# Altium schematic sheet Connection .SchDoc
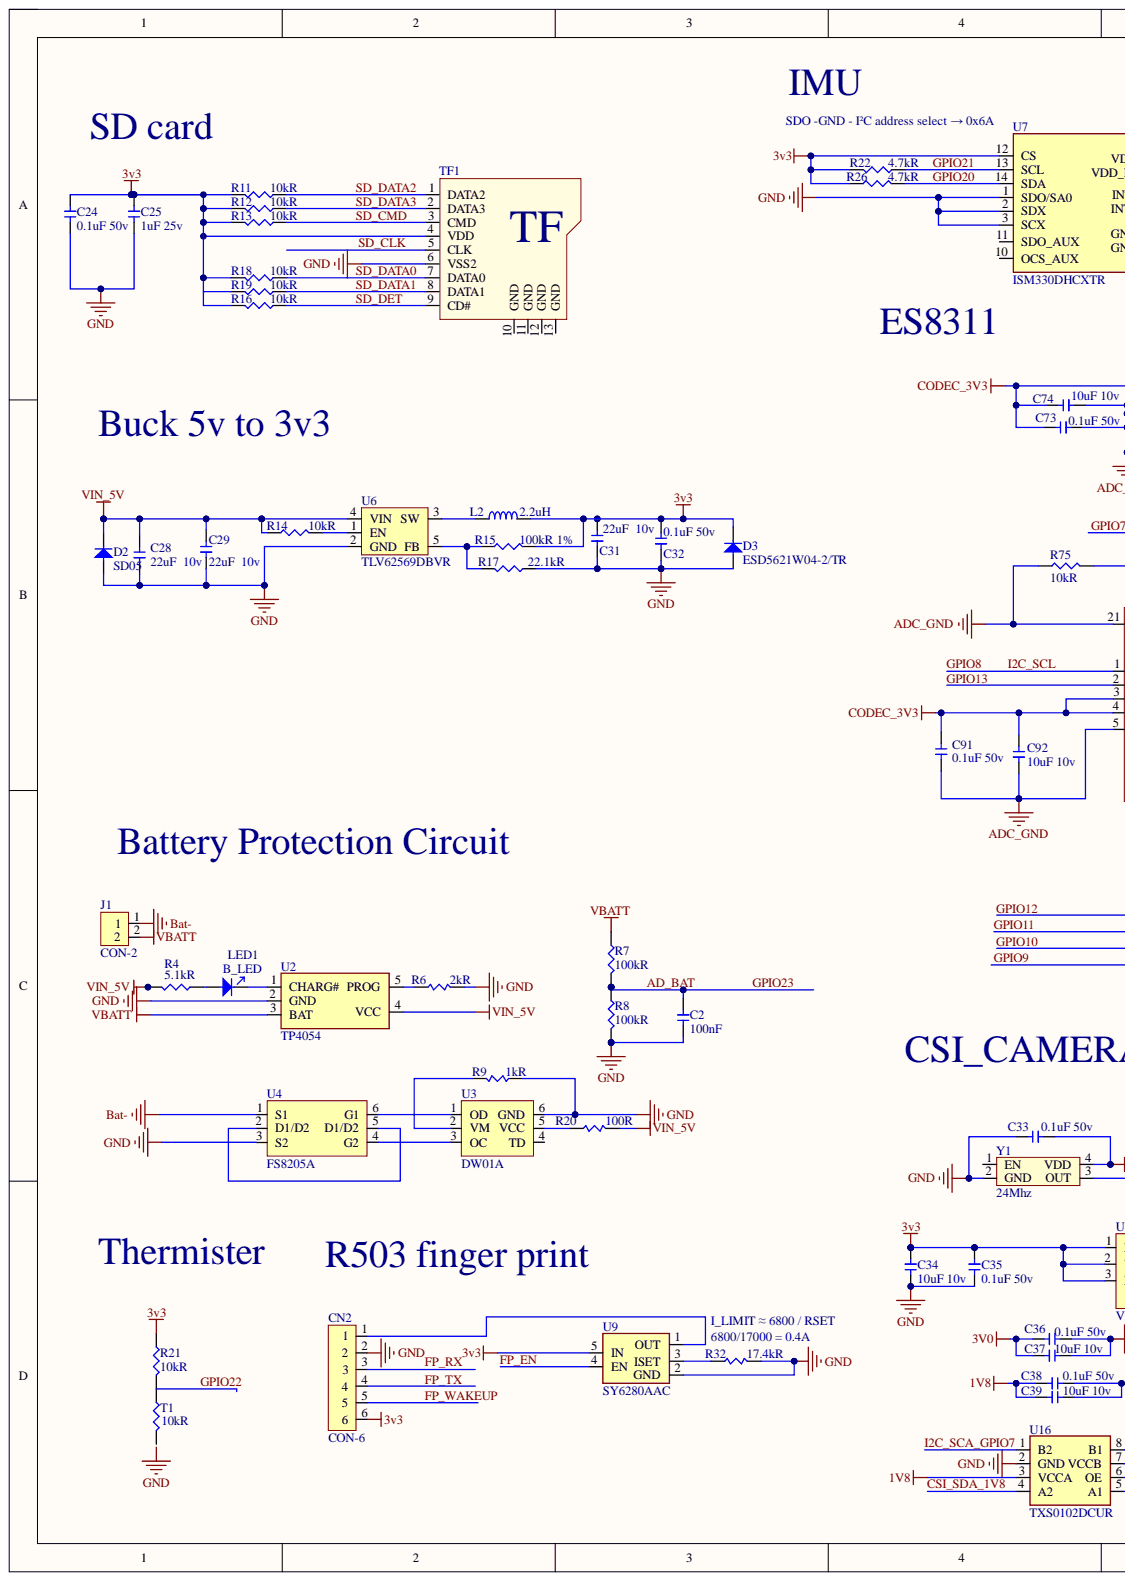
[diagram p0: Connection .SchDoc, left]
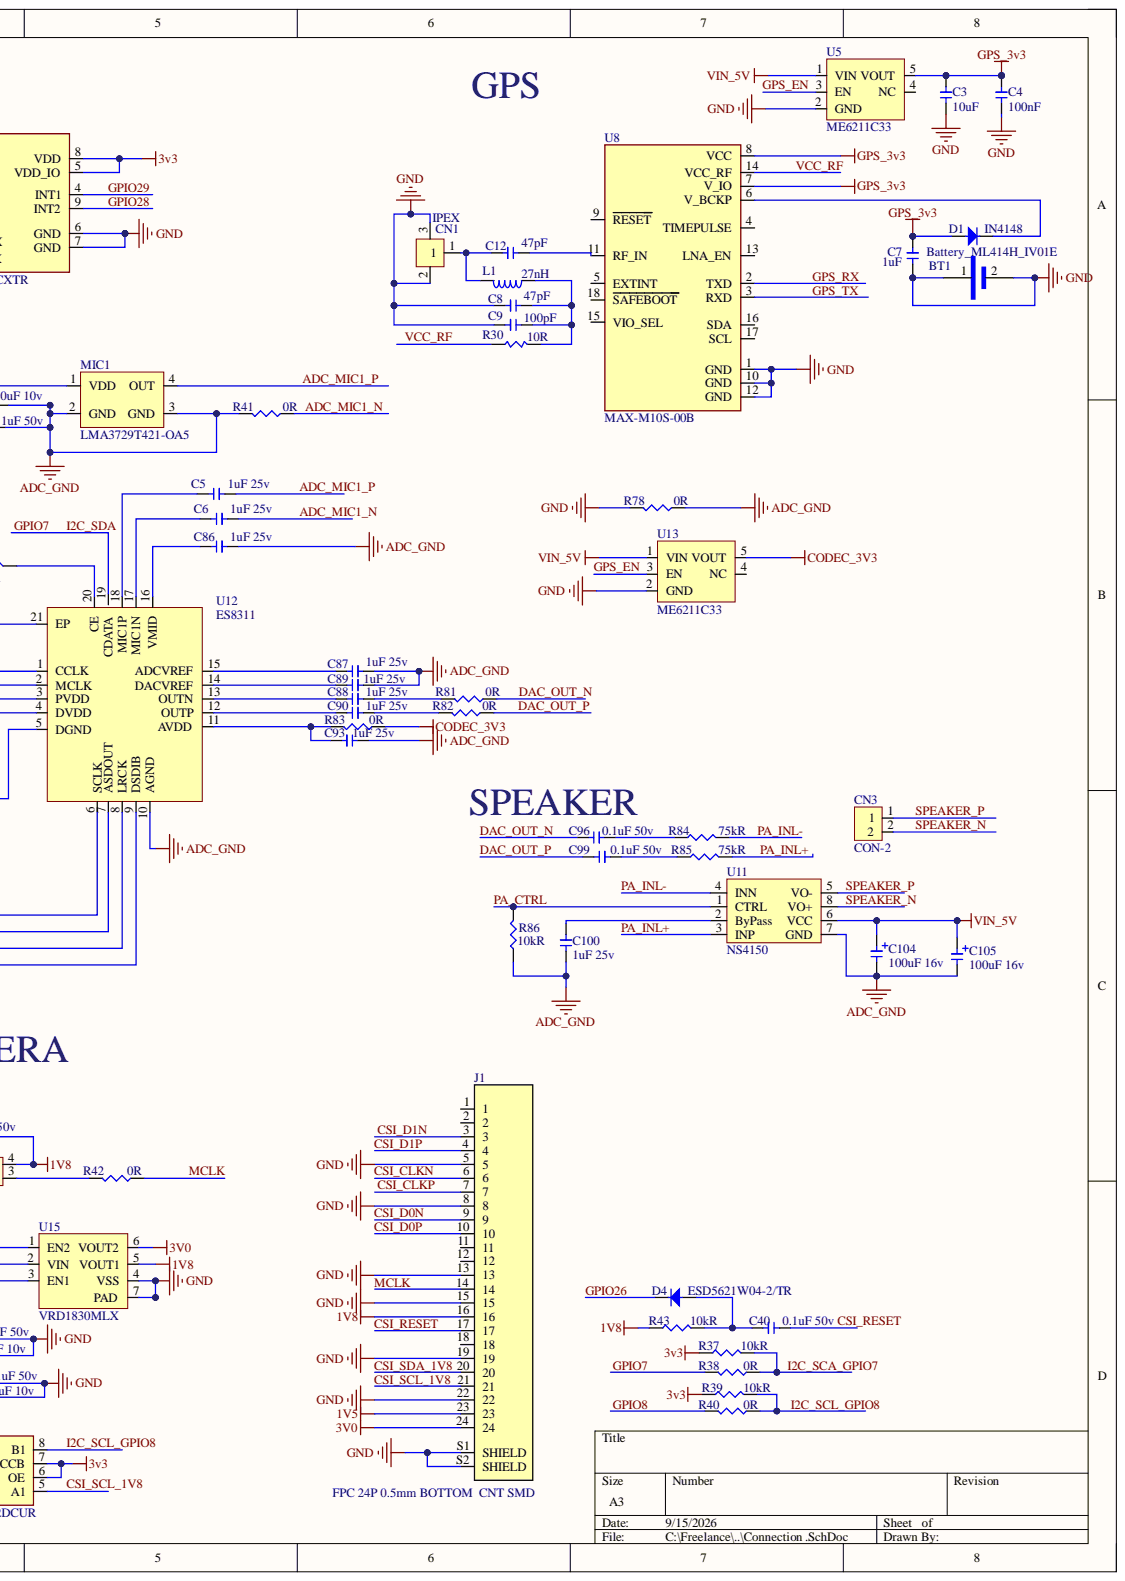
[diagram p1: Connection .SchDoc, right]

## Parts
BT1  Battery_ML414H_IV01E  [SEIKO_ML414H_IV01E]
C2  100nF  [0603C]
C3  10uF  [0603C]
C4  100nF  [0603C]
C5  1uF 25v  [0603C]
C6  1uF 25v  [0603C]
C7  1uF  [0603C]
C8  47pF  [0603C]
C9  100pF  [0603C]
C12  47pF  [0603C]
C24  0.1uF 50v  [0603C]
C25  1uF 25v  [0603C]
C28  22uF  10v  [0603C]
C29  22uF  10v  [0603C]
C31  22uF  10v  [0603C]
C32  0.1uF 50v  [0603C]
C33  0.1uF 50v  [0603C]
C34  10uF 10v  [0603C]
C35  0.1uF 50v  [0603C]
C36  0.1uF 50v  [0603C]
C37  10uF 10v  [0603C]
C38  0.1uF 50v  [0603C]
C39  10uF 10v  [0603C]
C40  0.1uF 50v  [0603C]
C73  0.1uF 50v  [0603C]
C74  10uF 10v  [0603C]
C86  1uF 25v  [0603C]
C87  1uF 25v  [0603C]
C88  1uF 25v  [0603C]
C89  1uF 25v  [0603C]
C90  1uF 25v  [0603C]
C91  0.1uF 50v  [0603C]
C92  10uF 10v  [0603C]
C93  1uF 25v  [0603C]
C96  0.1uF 50v  [0603C]
C99  0.1uF 50v  [0603C]
C100  1uF 25v  [0603C]
C104  100uF 16v  [1210C]
C105  100uF 16v  [1210C]
CN1  IPEX  [IPEX_20279-001E-03]
CN2  CON-6  [MOLEX 530470610 1.25MM 6P]
CN3  CON-2  [MOLEX 530470210 1.25mm 2p]
D1  IN4148  [SOD-123]
D2  SD05  [SOD323]
D3  ESD5621W04-2/TR  [SOD-323F]
D4  ESD5621W04-2/TR  [SOD-323F]
J1  FPC 24P 0.5mm BOTTOM  CNT SMD  [FPC 24P 0.5mm BOTTOM  CNT SMD]
J1  CON-2  [JST_S2B-PH-K-S(LF)(SN)]
L1  27nH  [0603L]
L2  2.2uH  [IND-3X3]
LED1  B_LED  [led0603 - blue]
MIC1  LMA3729T421-OA5  [SMD-4P_3.8x3mm]
R4  5.1kR  [0603]
R6  2kR  [0603]
R7  100kR  [0603]
R8  100kR  [0603]
R9  1kR  [0603]
R11  10kR  [0603]
R12  10kR  [0603]
R13  10kR  [0603]
R14  10kR  [0603]
R15  100kR 1%  [0603]
R16  10kR  [0603]
R17  22.1kR  [0603]
R18  10kR  [0603]
R19  10kR  [0603]
R20  100R  [0603]
R21  10kR  [0603]
R22  4.7kR  [0603]
R26  4.7kR  [0603]
R30  10R  [0603]
R32  17.4kR  [0603]
R37  10kR  [0603]
R38  0R  [0603]
R39  10kR  [0603]
R40  0R  [0603]
R41  0R  [0603]
R42  0R  [0603]
R43  10kR  [0603]
R75  10kR  [0603]
R78  0R  [0603]
R81  0R  [0603]
R82  0R  [0603]
R83  0R  [0603]
R84  75kR  [0603]
R85  75kR  [0603]
R86  10kR  [0603]
T1  10kR  [0603]
TF1  SD-MICRO-TF  [SD-MICRO-TF]  (value not drawn)
U2  TP4054  [SOT-23-5]
U3  DW01A  [SOT-23-6]
U4  FS8205A  [SOT-23-6]
U5  ME6211C33  [SOT-23-5]
U6  TLV62569DBVR  [SOT-23-5]
U7  ISM330DHCXTR  [VFLGA-14]
U8  MAX-M10S-00B  [XCVR_MAX-M10S-00B]
U9  SY6280AAC  [SOT95P285X130-5N]
U11  NS4150  [MSOP8]
U12  ES8311  [QFN-20]
U13  ME6211C33  [SOT-23-5]
U15  VRD1830MLX  [LLP-6(1.8x2)]
U16  TXS0102DCUR  [SOP50P310X90-8N]
Y1  24Mhz  [XTAL-3225]

## Nets
1V5: J1.23
1V8: C33.1 C38.1 C39.1 J1.16 R43.1 U15.5(VOUT1) U16.3(VCCA) Y1.4(VDD)
3V0: C24.1 C25.1 C31.2 C32.2 C34.1 C35.1 C36.1 C37.1 CN2.6 D3.C J1.24 L2.2 R11.2 R12.2 R13.2 R15.2 R16.2 R18.2 R19.2 R21.1 R22.2 R26.1 R37.1 R39.1 TF1.4(VDD) U7.5(VDD_IO) U7.8(VDD) U7.12(CS) U9.5(IN) U15.1(EN2) U15.2(VIN) U15.3(EN1) U15.6(VOUT2) U16.6(OE) U16.7(VCCB)
ADC_GND: C73.1 C74.1 C86.1 C87.1 C89.1 C91.1 C92.1 C93.2 C100.2 C104.2 C105.2 MIC1.2(GND) MIC1.3(GND) R41.1 R75.1 R78.2 R86.1 U11.7(GND) U12.5(DGND) U12.10(AGND) U12.21(EP)
Bat-: J1.1 U4.1(S1)
CODEC_3V3: C73.2 C74.2 C91.2 C92.2 MIC1.1(VDD) R83.2 U12.3(PVDD) U12.4(DVDD) U13.5(VOUT)
GND: BT1.1 BT1.2 C2.2 C3.2 C4.2 C7.2 C8.1 C9.1 C24.2 C25.2 C28.1 C29.1 C31.1 C32.1 C33.2 C34.2 C35.2 C36.2 C37.2 C38.2 C39.2 CN1.2 CN1.3 CN2.2 D2.A D3.A J1.5 J1.8 J1.13 J1.15 J1.19 J1.22 J1.S1(SHIELD) J1.S2(SHIELD) R6.2 R8.2 R9.1 R17.1 R32.2 R78.1 TF1.6(VSS2) U2.2(GND) U3.6(GND) U4.3(S2) U5.2(GND) U6.2(GND) U7.1(SDO/SA0) U7.2(SDX) U7.3(SCX) U7.6(GND) U7.7(GND) U8.1(GND) U8.10(GND) U8.12(GND) U9.2(GND) U13.2(GND) U15.4(VSS) U15.7(PAD) U16.2(GND) Y1.2(GND)
GPS_3v3: C3.1 C4.1 C7.1 D1.A U5.5(VOUT) U8.7(V_IO) U8.8(VCC)
VBATT: J1.2 R7.1 U2.3(BAT)
VIN_5V: C28.2 C29.2 C104.1 C105.1 D2.C R4.2 R14.1 R20.2 U2.4(VCC) U5.1(VIN) U6.4(VIN) U11.6(VCC) U13.1(VIN)
AD_BAT: C2.1 R7.2 R8.1
ADC_MIC1_N: C6.1 R41.2
ADC_MIC1_P: C5.1 MIC1.4(OUT)
CSI_CLKN: J1.6
CSI_CLKP: J1.7
CSI_D0N: J1.9
CSI_D0P: J1.10
CSI_D1N: J1.3
CSI_D1P: J1.4
CSI_RESET: C40.2 J1.17
CSI_SCL_1V8: J1.21 U16.5(A1)
CSI_SDA_1V8: J1.20 U16.4(A2)
DAC_OUT_N: C96.2 R81.2
DAC_OUT_P: C99.2 R82.2
FP_EN: U9.4(EN)
FP_RX: CN2.3
FP_TX: CN2.4
FP_WAKEUP: CN2.5
GPIO7: R38.1 U12.19(CDATA)
GPIO8: R40.1 U12.1(CCLK)
GPIO9: U12.9(DSDIB)
GPIO10: U12.8(LRCK)
GPIO11: U12.7(ASDOUT)
GPIO12: U12.6(SCLK)
GPIO13: U12.2(MCLK)
GPIO20: R26.2 U7.14(SDA)
GPIO21: R22.1 U7.13(SCL)
GPIO22: R21.2 T1.1
GPIO26: D4.C
GPIO28: U7.9(INT2)
GPIO29: U7.4(INT1)
GPS_EN: U5.3(EN) U13.3(EN)
GPS_RX: U8.2(TXD)
GPS_TX: U8.3(RXD)
I2C_SCA_GPIO7: R37.2 R38.2 U16.1(B2)
I2C_SCL_GPIO8: R39.2 R40.2 U16.8(B1)
MCLK: J1.14 R42.2
PA_CTRL: R86.2 U11.1(CTRL)
PA_INL+: R85.2 U11.3(INP)
PA_INL-: R84.2 U11.4(INN)
SD_CLK: TF1.5(CLK)
SD_CMD: R13.1 TF1.3(CMD)
SD_DATA0: R18.1 TF1.7(DATA0)
SD_DATA1: R19.1 TF1.8(DATA1)
SD_DATA2: R11.1 TF1.1(DATA2)
SD_DATA3: R12.1 TF1.2(DATA3)
SD_DET: R16.1 TF1.9(CD#)
SPEAKER_N: CN3.2 U11.8(VO+)
SPEAKER_P: CN3.1 U11.5(VO-)
VCC_RF: R30.1 U8.14(VCC_RF)
NetC5_2: C5.2 U12.18(MIC1P)
NetC6_2: C6.2 U12.17(MIC1N)
NetC8_2: C8.2 C9.2 L1.1 R30.2
NetC12_1: C12.1 CN1.1 L1.2
NetC12_2: C12.2 U8.11(RF_IN)
NetC40_1: C40.1 D4.A R43.2
NetC86_2: C86.2 U12.16(VMID)
NetC87_2: C87.2 U12.15(ADCVREF)
NetC88_1: C88.1 R81.1
NetC88_2: C88.2 U12.13(OUTN)
NetC89_2: C89.2 U12.14(DACVREF)
NetC90_1: C90.1 R82.1
NetC90_2: C90.2 U12.12(OUTP)
NetC93_1: C93.1 R83.1 U12.11(AVDD)
NetC96_1: C96.1 R84.1
NetC99_1: C99.1 R85.1
NetC100_1: C100.1 U11.2(ByPass)
NetCN2_1: CN2.1 U9.1(OUT)
NetD1_K: D1.K U8.6(V_BCKP)
NetL2_1: L2.1 U6.3(SW)
NetLED1_1: LED1.1 R4.1
NetLED1_2: LED1.2 U2.1(CHARG#)
NetR6_1: R6.1 U2.5(PROG)
NetR9_2: R9.2 U3.2(VM)
NetR14_2: R14.2 U6.1(EN)
NetR15_1: R15.1 R17.2 U6.5(FB)
NetR20_1: R20.1 U3.5(VCC)
NetR32_1: R32.1 U9.3(ISET)
NetR42_1: R42.1 Y1.3(OUT)
NetR75_2: R75.2 U12.20(CE)
NetU3_1: U3.1(OD) U4.6(G1)
NetU3_3: U3.3(OC) U4.4(G2)
NetU4_2: U4.2(D1/D2) U4.5(D1/D2)

Not connected: J1 11 12 18; T1 2; TF1 10(GND) 11(GND) 12(GND) 13(GND); U3 4(TD); U5 4(NC); U7 10(OCS_AUX) 11(SDO_AUX); U8 4(TIMEPULSE) 5(EXTINT) 9(RESET) 13(LNA_EN) 15(VIO_SEL) 16(SDA) 17(SCL) 18(SAFEBOOT); U13 4(NC); Y1 1(EN)

## Library data of the parts
BT1 = Battery_ML414H_IV01E: Coin, 4.8mm 3 V Lithium Battery Rechargeable (Secondary) 1mAh; Manufacturer: Seiko Instruments; PartNumber: ML414H IV01E; Component Kind: Standard; Library Name: BingRan_2019.SchLib
C2 C4 = CAP: 贴片电容（MLCC） 0603 100nF ±5% 25V X7R; Manufacturer: FH(风华); PartNumber: 0603B104J250NT; Component Kind: Standard; Library Name: BingRan_2019.SchLib
C3 = CAP: 贴片电容（MLCC） 0603 10µF ±20% 10V X5R; Manufacturer: Yageo(国巨); PartNumber: CC0603MRX5R6BB106; Component Kind: Standard; Library Name: BingRan_2019.SchLib
C5 C6 C25 C86-C90 C93 C100 = CAP: 贴片电容（MLCC） 0603 1µF ±10% 25V X7R; Manufacturer: FH(风华); PartNumber: 0603B105K250NT; Component Kind: Standard; Library Name: BingRan_2019.SchLib
C7 = CAP: 贴片电容（MLCC） 0603 1µF ±10% 25V X5R; Manufacturer: FH(风华); PartNumber: 0603X105K250NT; Component Kind: Standard; Library Name: BingRan_2019.SchLib
C8 C12 = CAP: 贴片电容（MLCC） 0603 47pF ±5% 25V C0G(NP0); Manufacturer: Yageo(国巨); PartNumber: CC0603JRNPO8BN470; Component Kind: Standard; Library Name: BingRan_2019.SchLib
C9 = CAP: 贴片电容（MLCC） 0603 100pF ±5% 50V C0G(NP0); Manufacturer: Yageo(国巨); PartNumber: CC0603JRNPO9BN101; Component Kind: Standard; Library Name: BingRan_2019.SchLib
C24 C32 C33 C35 C36 C38 C40 C73 C91 C96 C99 = CAP: 贴片电容（MLCC） 0603 100nF ±10% 50V X7R; Manufacturer: Yageo(国巨); PartNumber: CC0603KPX7R9BB104; Component Kind: Standard; Library Name: BingRan_2019.SchLib
C28 C29 C31 = CAP: 贴片电容（MLCC） 0603 22µF ±20% 10V Y5V; Manufacturer: FH(风华); PartNumber: 0603F226M100NT; Component Kind: Standard; Library Name: BingRan_2019.SchLib
C34 C37 C39 C74 C92 = CAP: 贴片电容（MLCC） 0603 10µF ±20% 10V X5R; Manufacturer: FH(风华); PartNumber: 0603X106M100NT; Component Kind: Standard; Library Name: BingRan_2019.SchLib
C104 C105 = CAP_POL: 贴片电容（MLCC） 1210 100µF ±20% 16V X5R; Manufacturer: Yageo(国巨); PartNumber: CC1210MKX5R7BB107; Component Kind: Standard; Library Name: BingRan_2019.SchLib
CN1 = IPEX: 射频和同轴连接器 立贴 天线连接座 镀金 内针; Manufacturer: XUNPU; PartNumber: IPEX-001; Component Kind: Standard; Library Name: BingRan_2019.SchLib
CN2 = CON-6: Connector Header Through Hole 6 position 0.049" (1.25mm); Manufacturer: MOLEX; PartNumber: 530470610; Component Kind: Standard; Library Name: BingRan_2019.SchLib
CN3 = CON-2: MOLEX  530470210 1.25mm 2p; Component Kind: Standard; Manufacturer: MOLEX; PartNumber: 530470210; Library Name: BingRan_2019.SchLib
D1 = DIODE: 4A 8ns 100V Independent 1.25V@150mA 300mA SOD-123 Single Diodes RoHS; Manufacturer: JSMSEMI; PartNumber: 1N4148W; Component Kind: Standard; Library Name: BingRan_2019.SchLib
D2 = DIODE: Uni PD=350W VRWM=5V VBR=6V VC=18V IPP=17A IR=10uA CJ=300PF; Manufacturer: MDD(辰达半导体); Component Kind: Standard; PartNumber: SD05; Library Name: BingRan_2019.SchLib
D3 D4 = DIODE: 1线，单向，瞬态电压抑制器; Manufacturer: WILLSEMI(韦尔); Component Kind: Standard; PartNumber: ESD5621W04-2/TR; Library Name: BingRan_2019.SchLib
J1 = FPC 24P 0.5mm BOTTOM  CNT SMD: CONN FFC FPC 24POS 0.5mm BOTTOM CNT SMD R/A; Manufacturer: SHOU HAN; PartNumber: 0.5-24P XJH2.0 043; Component Kind: Standard; Library Name: BingRan_2019.SchLib
J1 = CON-2: JST_S2B-PH-K-S(LF)(SN); Manufacturer: JST; Component Kind: Standard; PartNumber: S2B-PH-K-S(LF)(SN); Library Name: BingRan_2019.SchLib
L1 = INDUCTOR: 高频电感 27nH ±5% 540mΩ 500mA 0.80mm 1.60 x 0.80mm 0603; Manufacturer: Murata; PartNumber: LQG18HN27NJ00D; Component Kind: Standard; Library Name: BingRan_2019.SchLib
L2 = INDUCTOR: 功率电感 2.2µH ±20% IND_3X3MM_SM; Manufacturer: Sunlord; PartNumber: SWPA3012S2R2MT; Component Kind: Standard; Library Name: BingRan_2019.SchLib
LED1 = LED: LED NATIONSTAR 0603 蓝色FC-D1608BK-470H10; Component Kind: Standard; Manufacturer: LED NATIONSTAR; PartNumber: FC-D1608BK-470H10; Library Name: BingRan_2019.SchLib
MIC1 = MSM381A3729H9CP: Manufacturer: LinkMems; Component Kind: Standard; PartNumber: LMA3729T421-OA5; Library Name: BingRan_2019.SchLib
R4 R22 R26 = RES-0603: 贴片电阻 0603 5.1KΩ ±1% 1/10W ±100ppm/℃; Manufacturer: Yageo(国巨); PartNumber: RC0603FR-075K1L; Component Kind: Standard; Library Name: BingRan_2019.SchLib
R6 = RES: 贴片电阻 0603 2KΩ ±0.5% 1/10W ±25ppm/℃; Manufacturer: Yageo(国巨); PartNumber: RT0603DRD072KL; Component Kind: Standard; Library Name: BingRan_2019.SchLib
R7 R8 = RES-0603: 贴片电阻 0603 100KΩ ±1% 1/10W ±100ppm/℃; Manufacturer: Yageo(国巨); PartNumber: RC0603FR-07100KL; Component Kind: Standard; Library Name: BingRan_2019.SchLib
R9 = RES: 贴片电阻 0603 1KΩ ±5% 1/10W ±100ppm/℃; Manufacturer: Yageo(国巨); PartNumber: RC0603JR-071KL; Component Kind: Standard; Library Name: BingRan_2019.SchLib
R11-R14 R16 R18 R19 R21 R37 R39 R43 R75 R86 = RES-0603: 贴片电阻 0603 10KΩ ±1% 1/10W ±150ppm/℃; Manufacturer: Yageo(国巨); PartNumber: RC0603FR-0710KP; Component Kind: Standard; Library Name: BingRan_2019.SchLib
R15 = RES-0603: 贴片电阻 0603 100KΩ ±0.1% 1/10W ±25ppm/℃; Manufacturer: Yageo(国巨); PartNumber: RT0603BRD07100KL; Component Kind: Standard; Library Name: BingRan_2019.SchLib
R17 = RES-0603: 贴片电阻 0603 22.1KΩ ±1% 1/16W ±100ppm/℃; Manufacturer: Yageo(国巨); PartNumber: RC0603FR-0722K1L; Component Kind: Standard; Library Name: BingRan_2019.SchLib
R20 = RES: 贴片电阻 0603 100Ω ±1% 1/10W ±100ppm/℃; Manufacturer: Yageo(国巨); PartNumber: RC0603FR-07100RL; Component Kind: Standard; Library Name: BingRan_2019.SchLib
R30 = RES: 贴片电阻 0603 10Ω ±5% 1/10W ±200ppm/℃; Manufacturer: Yageo(国巨); PartNumber: RC0603JR-0710RL; Component Kind: Standard; Library Name: BingRan_2019.SchLib
R32 = RES: 贴片电阻 0603 17.4KΩ ±1% 1/10W ±100ppm/℃; Manufacturer: Yageo(国巨); PartNumber: RC0603FR-0717K4L; Component Kind: Standard; Library Name: BingRan_2019.SchLib
R38 R40-R42 R78 R81-R83 = RES-0603: 贴片电阻 0603 0Ω ±5% 1/10W; Manufacturer: Yageo(国巨); PartNumber: RC0603JR-070RL; Component Kind: Standard; Library Name: BingRan_2019.SchLib
R84 R85 = RES-0603: 贴片电阻 0603 75KΩ ±5% 1/10W ±100ppm/℃; Manufacturer: Yageo(国巨); PartNumber: RC0603JR-0775KL; Component Kind: Standard; Library Name: BingRan_2019.SchLib
T1 = RES-0603: NTC热敏电阻 10KΩ ±1% 3950K 0603; Manufacturer: Shiheng(时恒); PartNumber: CMFA 103F3950; Component Kind: Standard; Library Name: BingRan_2019.SchLib
TF1 = SD-MICRO-TF: 卡连接方式：自弹式 卡类型：MicroSD卡(TF卡) 连接器类型：卡座 插卡式 TF卡座; Manufacturer: HRO(韩荣); PartNumber: TF-01A; Component Kind: Standard; Library Name: BingRan_2019.SchLib
U2 = TP4054: 电池充电管理 SOT23-5 500mA 4.2V -40℃~+85℃; Manufacturer: UMW(友台); Component Kind: Standard; PartNumber: TP4054; Library Name: BingRan_2019.SchLib
U3 = DW01A: Battery protection IC protects lithium-ion/polymer batteries.; Manufacturer: PUOLOP; Component Kind: Standard; PartNumber: DW01A; Library Name: BingRan_2019.SchLib
U4 = FS8205A: MOSFET 20V 9A SOT-23-6; Manufacturer: TECH PUBLIC; Component Kind: Standard; PartNumber: FS8205A; Library Name: BingRan_2019.SchLib
U5 U13 = ME6211C33M5G-N: 线性稳压器/LDO 3.3V 500mA SOT23-5 70dB -40℃~+150℃; Manufacturer: MICRONE(南京微盟); Component Kind: Standard; PartNumber: ME6211C33M5G-N; Library Name: BingRan_2019.SchLib
U6 = TLV62569DBVR: 2.5V-5.5V input, 2-A high efficiency step-down buck converter in SOT23 and SOT563 package; Manufacturer: Texas Instruments; Component Kind: Standard; PartNumber: TLV62569DBVR; Library Name: BingRan_2019.SchLib
U7 = ISM330DHCXTR: Accelerometer, Gyroscope, Temperature, 6 Axis Sensor I2C, SPI Output; Manufacturer: STMicroelectronics; Component Kind: Standard; PartNumber: ISM330DHCXTR; Library Name: BingRan_2019.SchLib
U9 = SY6280AAC: USB Power Distribution SW Single 5.5V 1A 5-Pin SOT-23; Manufacturer: Silergy; Component Kind: Standard; PartNumber: SY6280AAC; Library Name: BingRan_2019.SchLib
U11 = NS4150: 3Wx1@4Ω Class D MSOP-8 Audio Amplifiers ROHS; Manufacturer: Shenzhen Nsiway Tech; PartNumber: NS4150B; Component Kind: Standard; Library Name: BingRan_2019.SchLib
U12 = ES8311: QFN-20-EP(3x3) Signal Switches, Multiplexers, Decoders ROHS; Manufacturer: Everest-semi(Everest Semiconductor); Component Kind: Standard; PartNumber: ES8311; Library Name: BingRan_2019.SchLib
U15 = VRD1828MLX: Manufacturer: AnaSem; Component Kind: Standard; PartNumber: VRD1830MLX; Library Name: BingRan_2019.SchLib
U16 = TXS0102DCUR: 2-Bit Bidirectional Voltage-Level Shifter for Open-Drain and Push-Pull Application; MF: Texas Instruments; Check_prices: https://www.snapeda.com/parts/TXS0102DCUR/Texas+Instruments/view-part/?ref=eda; Component Kind: Standard; MP: TXS0102DCUR; Package: VSSOP-8 Texas Instruments; SnapEDA_Link: https://www.snapeda.com/parts/TXS0102DCUR/Texas+Instruments/view-part/?ref=snap; Library Name: BingRan_2019.SchLib
Y1 = XTAL_3225_1: XTAL OSC 24MHz CMOS SMD; Manufacturer: CHANGXING ELECTRONICS; PartNumber: 8ESC240C0033L; Component Kind: Standard; Library Name: BingRan_2019.SchLib
U8 = MAX-M10S-00B: Availability: Unavailable; Component Kind: Standard; MP: MAX-M10S-00B; Package: None; Price: None; Library Name: BingRan_2019.SchLib
